# Altium schematic sheet MCUCourseBoard.SchDoc(MCUCourseBoard)
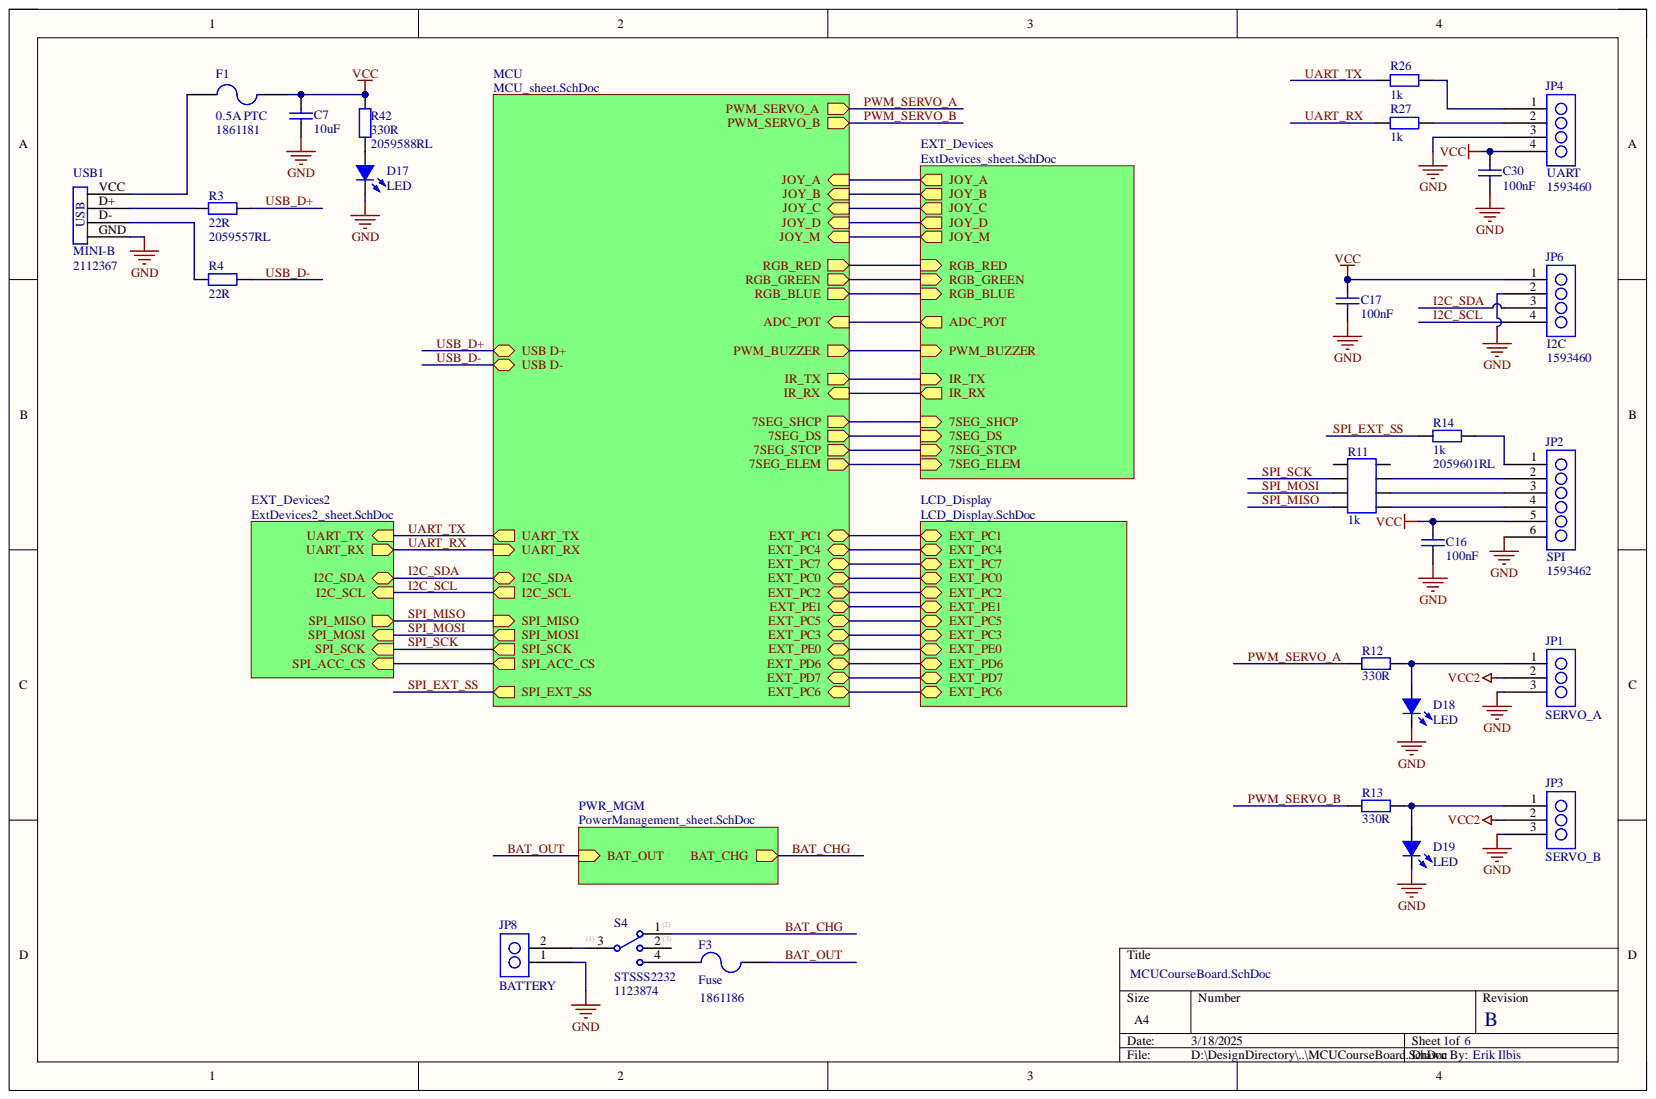
[diagram p0: MCUCourseBoard.SchDoc]

## Parts
C7  10uF  [CAP_0805]
C16  100nF  [CAP_0603]
C17  100nF  [CAP_0603]
C30  100nF  [CAP_0603]
D17  LED  [LED_0603]
D18  LED  [LED_0603]
D19  LED  [LED_0603]
F1  0.5A PTC  Supplier Part Number 1: 1861181  [PTC_1210]
F3  Fuse  Supplier Part Number 1: 1861186  [PTC_1210]
JP1  SERVO_A  [HDR1X3]
JP2  SPI  Supplier Part Number 1: 1593462  [HDR1X6]
JP3  SERVO_B  [HDR1X3]
JP4  UART  Supplier Part Number 1: 1593460  [HDR1X4]
JP6  I2C  Supplier Part Number 1: 1593460  [HDR1X4]
JP8  BATTERY  [HDR1X2]
R3  22R  [RES_0603]
R4  22R  [RES_0603]
R11  1k  [ARRAY_1206]
R12  330R  [RES_0603]
R13  330R  [RES_0603]
R14  1k  [RES_0603]
R26  1k  [RES_0603]
R27  1k  [RES_0603]
R42  330R  Supplier Part Number 1: 2059588RL  [RES_0603]
S4  STSSS2232  Supplier Part Number 1: 1123874  [STSS2232]
USB1  MINI-B  Supplier Part Number 1: 2112367  [USB MiniB SMD]

## Nets
GND: C7.1 C16.1 C17.1 C30.1 D17.2 D18.2 D19.2 JP1.3 JP2.6 JP3.3 JP4.3 JP6.2 JP8.1 USB1.GND
VCC: C7.2 C16.2 C17.2 C30.2 F1.2 JP2.5 JP4.4 JP6.1 R42.1
VCC2: JP1.2 JP3.2
BAT_CHG: S4.2
BAT_OUT: F3.2
I2C_SCL: JP6.4
I2C_SDA: JP6.3
PWM_SERVO_A: R12.1
PWM_SERVO_B: R13.1
SPI_EXT_SS: R14.1
SPI_MISO: R11.4B
SPI_MOSI: R11.3B
SPI_SCK: R11.2B
UART_RX: R27.1
UART_TX: R26.1
USB_D+: R3.2
USB_D-: R4.2
NetD17_1: D17.1 R42.2
NetD18_1: D18.1 JP1.1 R12.2
NetD19_1: D19.1 JP3.1 R13.2
NetF1_1: F1.1 USB1.VCC
NetF3_1: F3.1 S4.4
NetJP2_1: JP2.1 R14.2
NetJP2_2: JP2.2 R11.2A
NetJP2_3: JP2.3 R11.3A
NetJP2_4: JP2.4 R11.4A
NetJP4_1: JP4.1 R26.2
NetJP4_2: JP4.2 R27.2
NetJP8_2: JP8.2 S4.1
NetR3_1: R3.1 USB1.D+
NetR4_1: R4.1 USB1.D-
NetR11_1A: R11.1A
NetR11_1B: R11.1B
NetS4_3: S4.3
7SEG_DS:
7SEG_ELEM:
7SEG_SHCP:
7SEG_STCP:
ADC_POT:
EXT_PC0:
EXT_PC1:
EXT_PC2:
EXT_PC3:
EXT_PC4:
EXT_PC5:
EXT_PC6:
EXT_PC7:
EXT_PD6:
EXT_PD7:
EXT_PE0:
EXT_PE1:
IR_RX:
IR_TX:
JOY_A:
JOY_B:
JOY_C:
JOY_D:
JOY_M:
PWM_BUZZER:
RGB_BLUE:
RGB_GREEN:
RGB_RED:
SPI_ACC_CS:

## Library data of the parts
C7 C16 C17 C30 = Capacitor: Ceramic capacitor; Component Kind: Standard; Library Name: Erik Library.IntLib
D17-D19 = LED: Typical INFRARED GaAs LED; Component Kind: Standard; LatestRevisionDate: 08-Jul-2005; LatestRevisionNote: Mech Layer 1 removed.; PackageDescription: LED; 2 Leads; PackageReference: LED-0; Published: 8-Jun-2000; Library Name: Erik Library.IntLib; Publisher: Altium Limited
F1 = Fuse: Component Kind: Standard; Description: Fuse; Supplier 1: Farnell; Library Name: Erik Library.IntLib
JP1 JP3 = PIN_1_3: Component Kind: Standard; Library Name: Erik Library.IntLib
JP2 = PIN_1_6: Component Kind: Standard; Supplier 1: Farnell; Library Name: Erik Library.IntLib
JP4 JP6 = PIN_1_4: Component Kind: Standard; Supplier 1: Farnell; Library Name: Erik Library.IntLib
JP8 = PIN_1_2: Component Kind: Standard; Library Name: Erik Library.IntLib
R3 R4 R12-R14 R26 R27 R42 = Resistor: Component Kind: Standard; Description: Resistor; Library Name: Erik Library.IntLib
  R3: Supplier 1: Farnell; Supplier Part Number 1: 2059557RL
  R14: Supplier 1: Farnell; Supplier Part Number 1: 2059601RL
  R42: Supplier 1: Farnell
R11 = Resistor Array: Component Kind: Standard; Library Name: Erik Library.IntLib
S4 = SW-DPTT: SPDT Subminiature Toggle Switch, Right Angle Mounting, Vertical Actuation; Component Kind: Standard; Supplier 1: Farnell; Library Name: Erik Library.IntLib
USB1 = USB: Component Kind: Standard; Supplier 1: Farnell; Library Name: Erik Library.IntLib
F3 = Fuse: Component Kind: Standard; Description: Fuse; Supplier 1: Farnell; Library Name: Erik Library.IntLib
